# Altium schematic sheet RELAY SUPPLY_SWITCH .SchDoc("RELAY SUPPLY_SWITCH ")
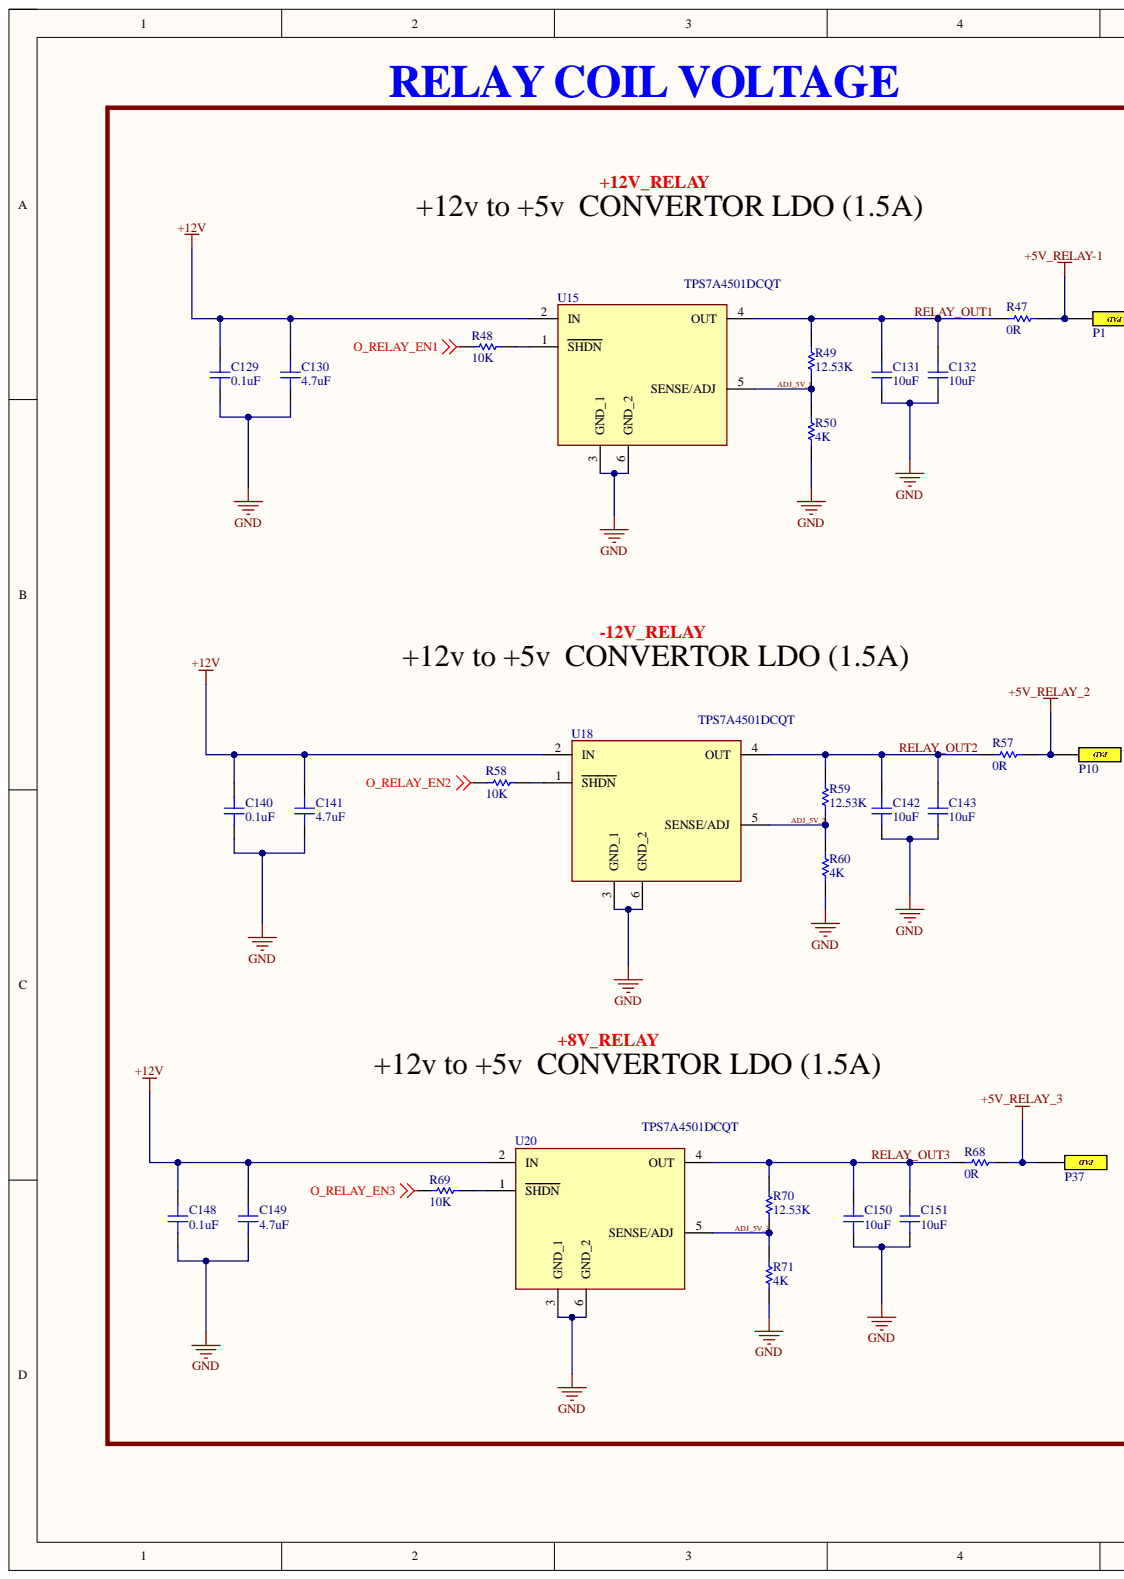
[diagram p0: RELAY SUPPLY_SWITCH .SchDoc, left]
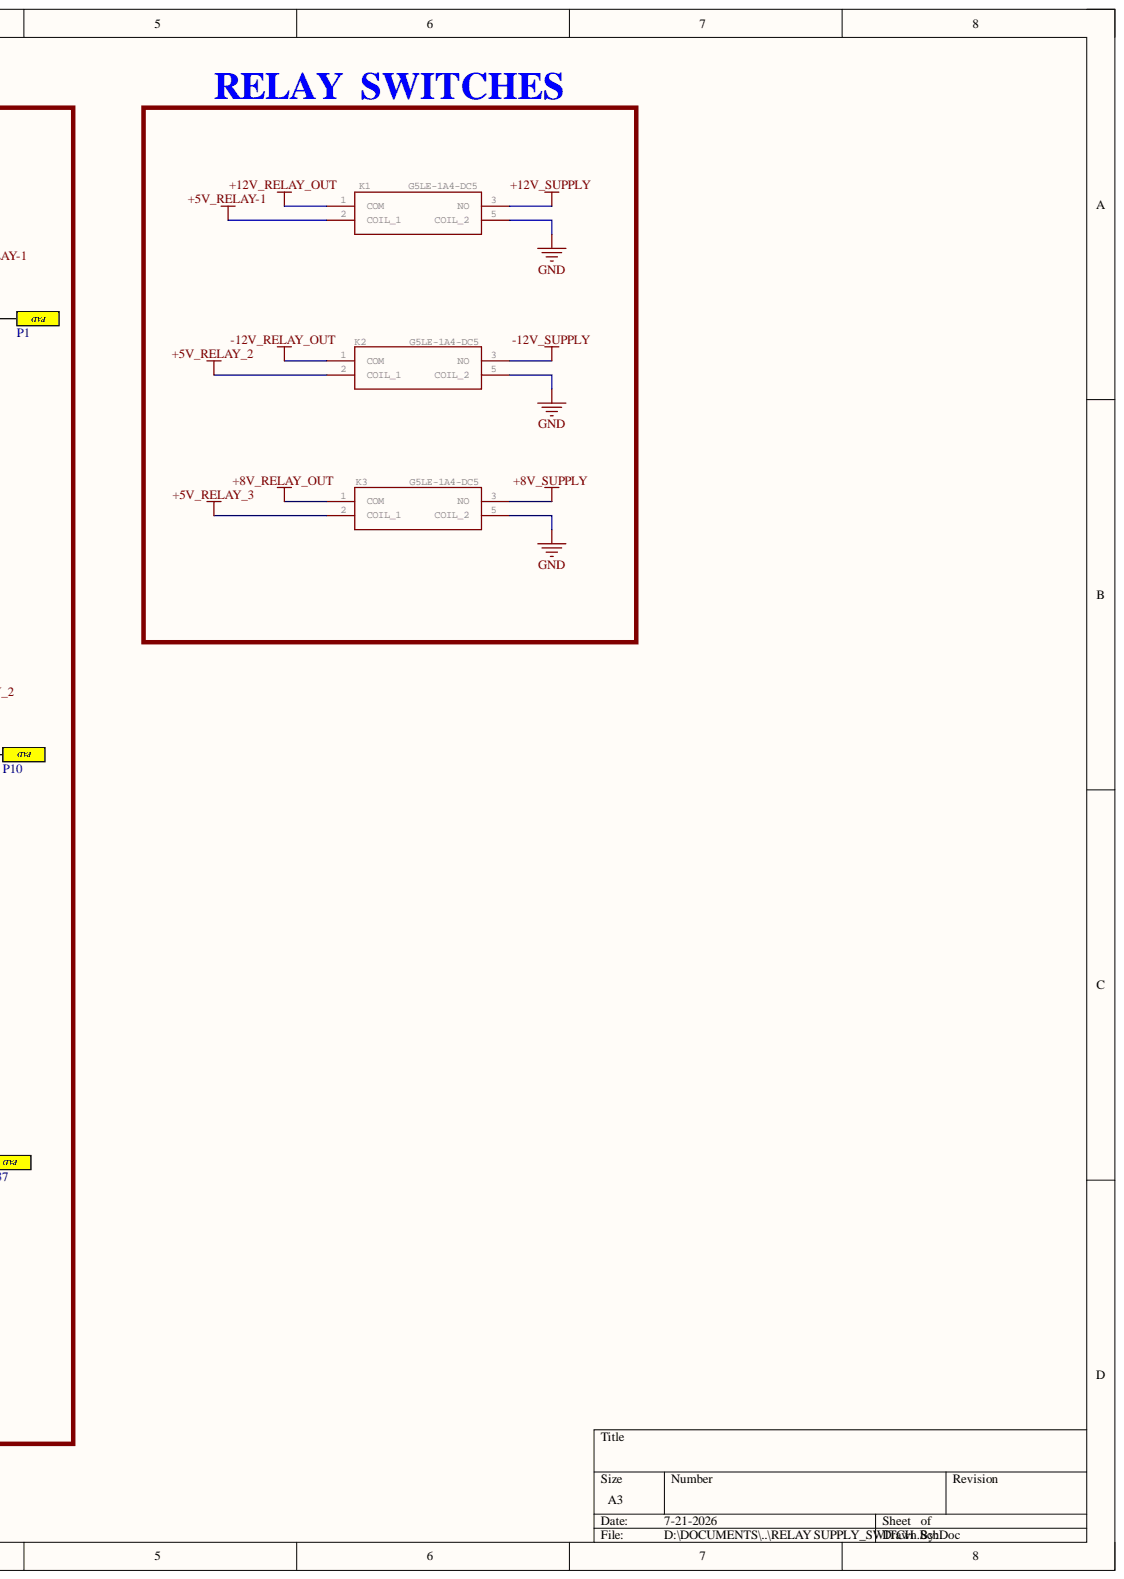
[diagram p1: RELAY SUPPLY_SWITCH .SchDoc, right]

## Parts
C129  0.1uF  [CAP_0603]
C130  4.7uF  [CAP_0603]
C131  10uF  [CAP_0603]
C132  10uF  [CAP_0603]
C140  0.1uF  [CAP_0603]
C141  4.7uF  [CAP_0603]
C142  10uF  [CAP_0603]
C143  10uF  [CAP_0603]
C148  0.1uF  [CAP_0603]
C149  4.7uF  [CAP_0603]
C150  10uF  [CAP_0603]
C151  10uF  [CAP_0603]
K1  G5LE-1A4-DC5  [G5LE1A4DC5]
K2  G5LE-1A4-DC5  [G5LE1A4DC5]
K3  G5LE-1A4-DC5  [G5LE1A4DC5]
P1  PAD_SMD_1.5x1mm  [PAD 1.5 X 1]  (value not drawn)
P10  PAD_SMD_1.5x1mm  [PAD 1.5 X 1]  (value not drawn)
P37  PAD_SMD_1.5x1mm  [PAD 1.5 X 1]  (value not drawn)
R47  0R  [RES_0805]
R48  10K  [RES_0402]
R49  12.53K  [RES_0402]
R50  4K  [RES_0402]
R57  0R  [RES_0805]
R58  10K  [RES_0402]
R59  12.53K  [RES_0402]
R60  4K  [RES_0402]
R68  0R  [RES_0805]
R69  10K  [RES_0402]
R70  12.53K  [RES_0402]
R71  4K  [RES_0402]
U15  TPS7A4501DCQT  [SOT127P706X180-6N]
U18  TPS7A4501DCQT  [SOT127P706X180-6N]
U20  TPS7A4501DCQT  [SOT127P706X180-6N]

## Nets
+5V_RELAY-1: K1.2(COIL_1) P1.1 R47.2
+5V_RELAY_2: K2.2(COIL_1) P10.1 R57.2
+5V_RELAY_3: K3.2(COIL_1) P37.1 R68.2
+8V_RELAY_OUT: K3.1(COM)
+8V_SUPPLY: K3.3(NO)
+12V: C129.2 C130.2 C140.2 C141.2 C148.2 C149.2 U15.2(IN) U18.2(IN) U20.2(IN)
+12V_RELAY_OUT: K1.1(COM)
+12V_SUPPLY: K1.3(NO)
-12V_RELAY_OUT: K2.1(COM)
-12V_SUPPLY: K2.3(NO)
GND: C129.1 C130.1 C131.1 C132.1 C140.1 C141.1 C142.1 C143.1 C148.1 C149.1 C150.1 C151.1 K1.5(COIL_2) K2.5(COIL_2) K3.5(COIL_2) R50.1 R60.1 R71.1 U15.3(GND_1) U15.6(GND_2) U18.3(GND_1) U18.6(GND_2) U20.3(GND_1) U20.6(GND_2)
O_RELAY_EN1: R48.2
O_RELAY_EN2: R58.2
O_RELAY_EN3: R69.2
ADJ_5V_1: R49.1 R50.2 U15.5(SENSE/ADJ)
ADJ_5V_2: R59.1 R60.2 U18.5(SENSE/ADJ)
ADJ_5V_3: R70.1 R71.2 U20.5(SENSE/ADJ)
RELAY_OUT1: C131.2 C132.2 R47.1 R49.2 U15.4(OUT)
RELAY_OUT2: C142.2 C143.2 R57.1 R59.2 U18.4(OUT)
RELAY_OUT3: C150.2 C151.2 R68.1 R70.2 U20.4(OUT)
NetR48_1: R48.1 U15.1(SHDN)
NetR58_1: R58.1 U18.1(SHDN)
NetR69_1: R69.1 U20.1(SHDN)

## Library data of the parts
C129-C132 C140-C143 C148-C151 = CAP_0603_25V: C0603_25V; Component Kind: Standard; Library Name: ALTIUM_LIB.SchLib
K1-K3 = G5LE-1A4-DC5: Manufacturer_Name: OMRON Electronics; GateName_1: G$1; Component Kind: Standard; DeviceSetName: G5LE-1A4-DC5; Height: 19mm; Library Name: MAX10.SchLib; LibraryName: G5LE-1A4-DC5; Manufacturer_Part_Number: G5LE-1A4-DC5; Mouser_Part_Number: 653-G5LE-1A4-DC5; Mouser_Price-Stock: https://www.mouser.co.uk/ProductDetail/Omron-Electronics/G5LE-1A4-DC5?qs=pWf36BUtxBgFTk6ytLB7NQ%3D%3D; Package: G5LE1A4DC5; SymbolName_1: G5LE-1A4-DC5
P1 P10 P37 = PAD_SMD_1.5x1mm: Testpoint; Component Kind: Standard; Library Name: ANAND_LIB.SchLib
R47 R57 R68 = RES_0805: R0805; Component Kind: Standard; Library Name: ALTIUM_LIB.SchLib
R48-R50 R58-R60 R69-R71 = RES_0402: R0402; Component Kind: Standard; Library Name: ALTIUM_LIB.SchLib
U15 U18 U20 = TPS7A4501DCQT: LOW-NOISE FAST-TRANSIENT-RESPONSE 1.5-A LOW-DROPOUT VOLTAGE%D REGULATORS; Manufacturer_Name: Texas Instruments; Geometry.Height: 1.8mm; Component Kind: Standard; Datasheet Link: https://datasheet.datasheetarchive.com/originals/distributors/Datasheets-SFU2/DSASFU100034255.pdf; Library Name: ALTIUM_LIB.SchLib; Manufacturer_Part_Number: TPS7A4501DCQT; Mouser Part Number: 595-TPS7A4501DCQT; Mouser Price/Stock: https://www.mouser.co.uk/ProductDetail/Texas-Instruments/TPS7A4501DCQT?qs=g0HfZ8wh75DefRsz0DtlDw%3D%3D
